# Altium schematic sheet aux_power_gate_driving.SchDoc(aux_power_gate_driving)
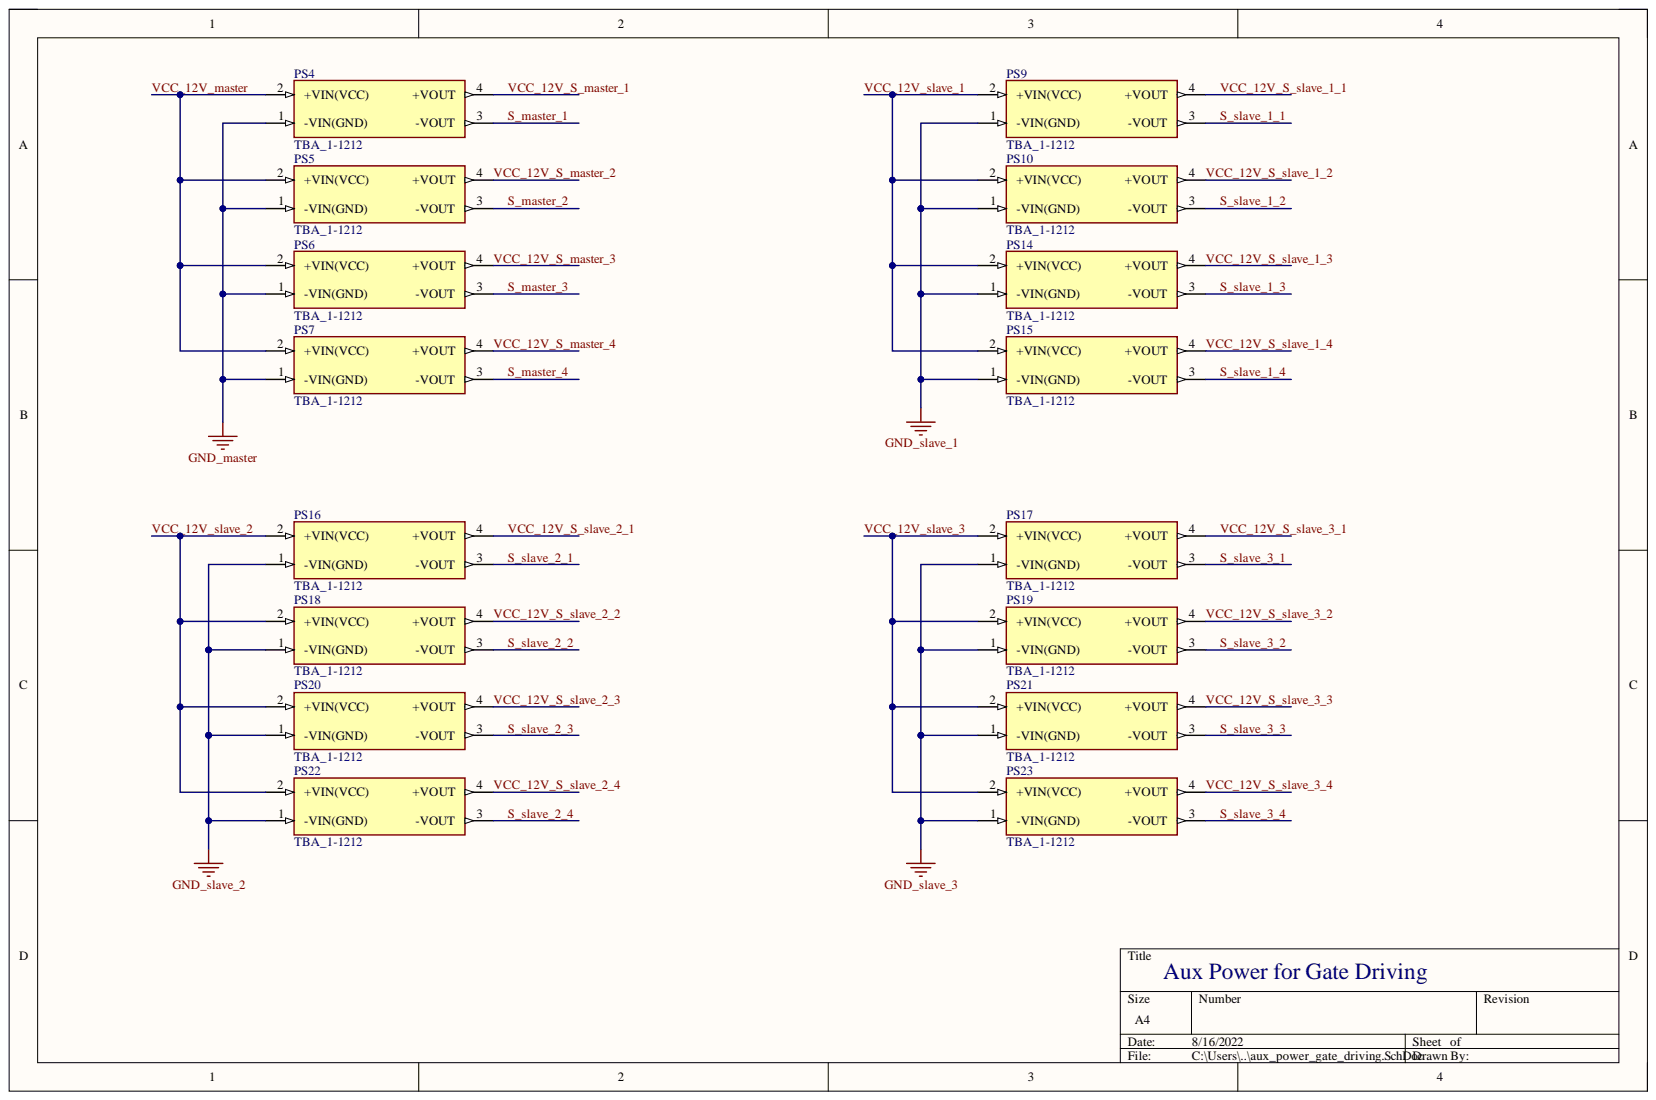
[diagram p0: aux_power_gate_driving.SchDoc]

## Parts
PS4  TBA_1-1212  [CONV_TBA_1-1212]
PS5  TBA_1-1212  [CONV_TBA_1-1212]
PS6  TBA_1-1212  [CONV_TBA_1-1212]
PS7  TBA_1-1212  [CONV_TBA_1-1212]
PS9  TBA_1-1212  [CONV_TBA_1-1212]
PS10  TBA_1-1212  [CONV_TBA_1-1212]
PS14  TBA_1-1212  [CONV_TBA_1-1212]
PS15  TBA_1-1212  [CONV_TBA_1-1212]
PS16  TBA_1-1212  [CONV_TBA_1-1212]
PS17  TBA_1-1212  [CONV_TBA_1-1212]
PS18  TBA_1-1212  [CONV_TBA_1-1212]
PS19  TBA_1-1212  [CONV_TBA_1-1212]
PS20  TBA_1-1212  [CONV_TBA_1-1212]
PS21  TBA_1-1212  [CONV_TBA_1-1212]
PS22  TBA_1-1212  [CONV_TBA_1-1212]
PS23  TBA_1-1212  [CONV_TBA_1-1212]

## Nets
GND_master: PS4.1(-VIN(GND)) PS5.1(-VIN(GND)) PS6.1(-VIN(GND)) PS7.1(-VIN(GND))
GND_slave_1: PS9.1(-VIN(GND)) PS10.1(-VIN(GND)) PS14.1(-VIN(GND)) PS15.1(-VIN(GND))
GND_slave_2: PS16.1(-VIN(GND)) PS18.1(-VIN(GND)) PS20.1(-VIN(GND)) PS22.1(-VIN(GND))
GND_slave_3: PS17.1(-VIN(GND)) PS19.1(-VIN(GND)) PS21.1(-VIN(GND)) PS23.1(-VIN(GND))
S_master_1: PS4.3(-VOUT)
S_master_2: PS5.3(-VOUT)
S_master_3: PS6.3(-VOUT)
S_master_4: PS7.3(-VOUT)
S_slave_1_1: PS9.3(-VOUT)
S_slave_1_2: PS10.3(-VOUT)
S_slave_1_3: PS14.3(-VOUT)
S_slave_1_4: PS15.3(-VOUT)
S_slave_2_1: PS16.3(-VOUT)
S_slave_2_2: PS18.3(-VOUT)
S_slave_2_3: PS20.3(-VOUT)
S_slave_2_4: PS22.3(-VOUT)
S_slave_3_1: PS17.3(-VOUT)
S_slave_3_2: PS19.3(-VOUT)
S_slave_3_3: PS21.3(-VOUT)
S_slave_3_4: PS23.3(-VOUT)
VCC_12V_master: PS4.2(+VIN(VCC)) PS5.2(+VIN(VCC)) PS6.2(+VIN(VCC)) PS7.2(+VIN(VCC))
VCC_12V_S_master_1: PS4.4(+VOUT)
VCC_12V_S_master_2: PS5.4(+VOUT)
VCC_12V_S_master_3: PS6.4(+VOUT)
VCC_12V_S_master_4: PS7.4(+VOUT)
VCC_12V_S_slave_1_1: PS9.4(+VOUT)
VCC_12V_S_slave_1_2: PS10.4(+VOUT)
VCC_12V_S_slave_1_3: PS14.4(+VOUT)
VCC_12V_S_slave_1_4: PS15.4(+VOUT)
VCC_12V_S_slave_2_1: PS16.4(+VOUT)
VCC_12V_S_slave_2_2: PS18.4(+VOUT)
VCC_12V_S_slave_2_3: PS20.4(+VOUT)
VCC_12V_S_slave_2_4: PS22.4(+VOUT)
VCC_12V_S_slave_3_1: PS17.4(+VOUT)
VCC_12V_S_slave_3_2: PS19.4(+VOUT)
VCC_12V_S_slave_3_3: PS21.4(+VOUT)
VCC_12V_S_slave_3_4: PS23.4(+VOUT)
VCC_12V_slave_1: PS9.2(+VIN(VCC)) PS10.2(+VIN(VCC)) PS14.2(+VIN(VCC)) PS15.2(+VIN(VCC))
VCC_12V_slave_2: PS16.2(+VIN(VCC)) PS18.2(+VIN(VCC)) PS20.2(+VIN(VCC)) PS22.2(+VIN(VCC))
VCC_12V_slave_3: PS17.2(+VIN(VCC)) PS19.2(+VIN(VCC)) PS21.2(+VIN(VCC)) PS23.2(+VIN(VCC))

## Library data of the parts
PS4-PS7 PS9 PS10 PS14-PS23 = TBA_1-1212: Availability: Unavailable; Component Kind: Standard; Library Name: TBA_1-1212.SchLib; MP: TBA_1-1212; Package: None; Price: None
